# Altium schematic sheet E53_ST1.SchDoc
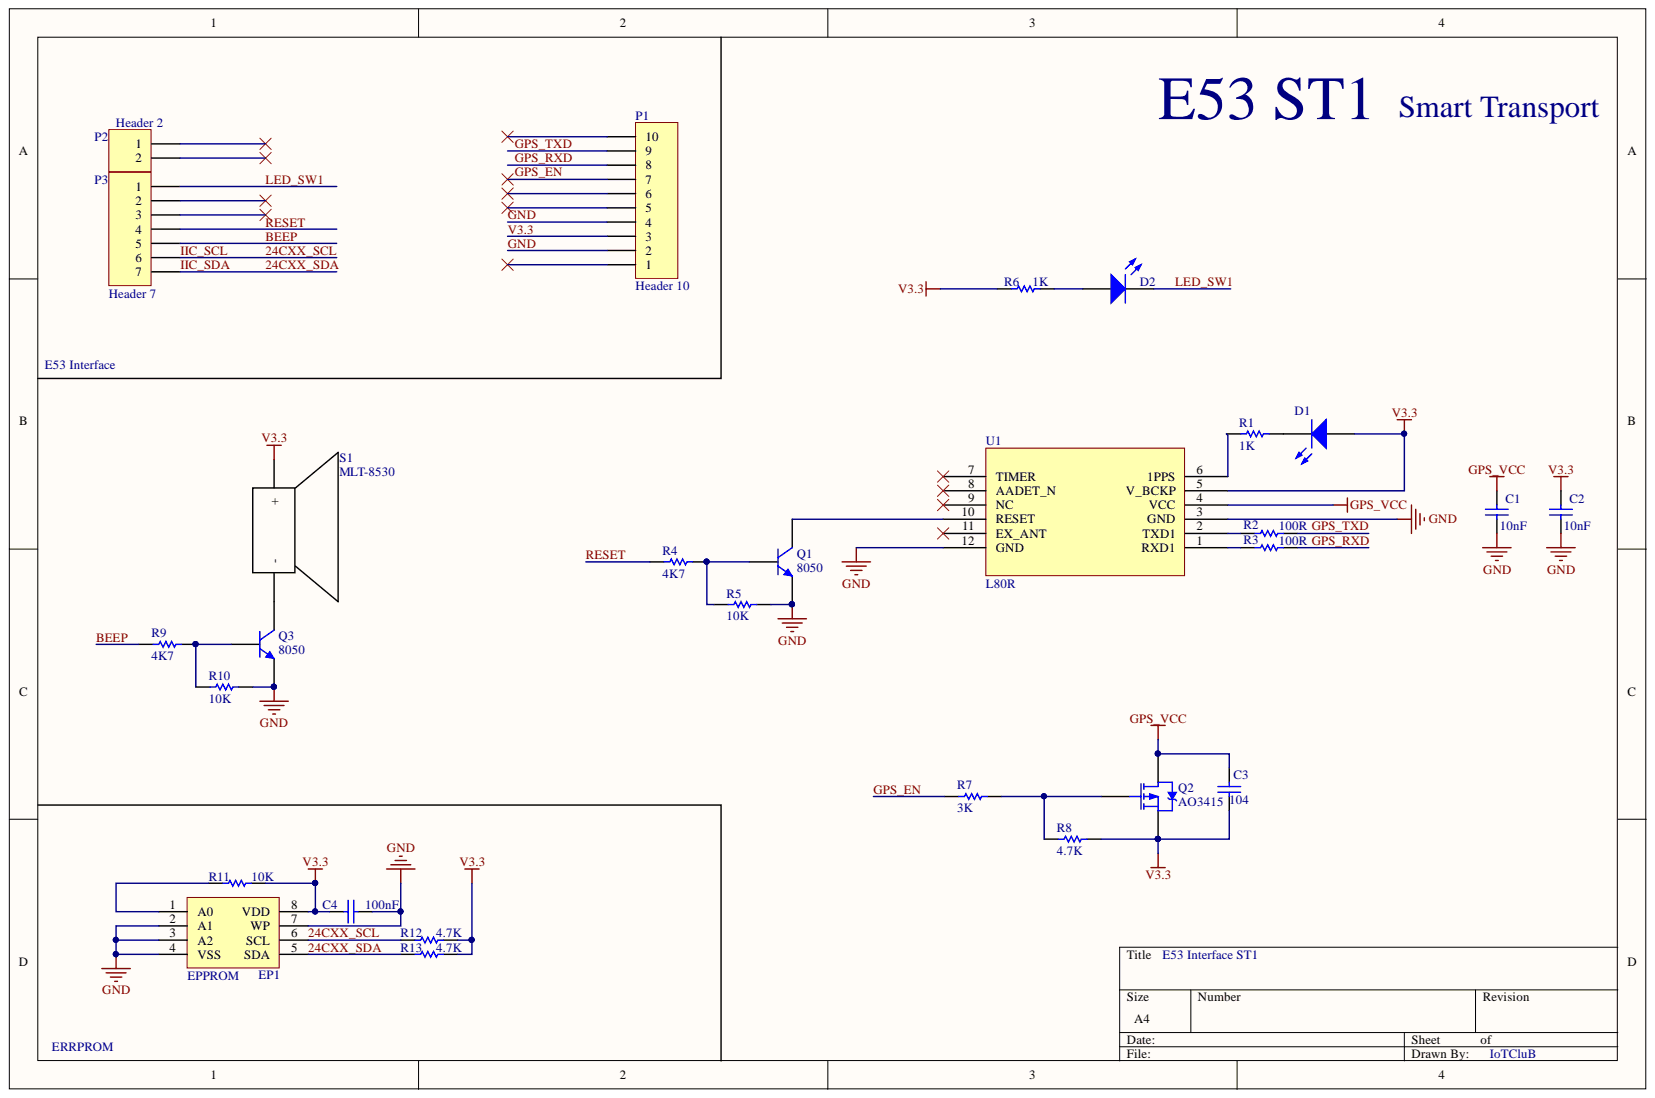
[diagram p0: E53_ST1.SchDoc]

## Parts
C1  10nF  [C0603]
C2  10nF  [C0603]
C3  value 104  [C0603]  (hidden comment: CAP)
C4  100nF  [C0603]
D1  LED  [LED_0805]  (value not drawn)
D2  LED  [LED_0805]
EP1  EPPROM  [SO-8]
P1  Header 10  [HDR1X10]
P2  Header 2  [HDR1X2]
P3  Header 7  [HDR1X7]
Q1  8050  [SOT23_N - 123]
Q2  AO3415  [SOT23_N]
Q3  8050  [SOT23_N - 123]
R1  1K  [R0603]
R2  100R  [R0603]
R3  100R  [R0603]
R4  4K7  [R0603]
R5  10K  [R0603]
R6  1K  [R0603]
R7  3K  [R0603]
R8  4.7K  [R0603]
R9  4K7  [R0603]
R10  10K  [R0603]
R11  10K  [R0603]
R12  4.7K  [R0603]
R13  4.7K  [R0603]
S1  MLT-8530  [MLT8530]
U1  L80R  [L80R]

## Nets
GPS_VCC: C1.1 C3.2 Q2.2 U1.4
24CXX_SCL: EP1.6 P3.6 R12.2
24CXX_SDA: EP1.5 P3.7 R13.2
BEEP: P3.5 R9.1
GND: C1.2 C2.2 C4.1 EP1.2 EP1.3 EP1.4 EP1.7 P1.2 P1.4 Q1.2 Q3.2 R5.1 R10.2 U1.3 U1.12
GPS_EN: P1.7 R7.2
GPS_RXD: P1.8 R3.2
GPS_TXD: P1.9 R2.2
LED_SW1: D2.2 P3.1
RESET: P3.4 R4.1
V3.3: C2.1 C3.1 C4.2 D1.1 EP1.8 P1.3 Q2.3 R6.1 R8.2 R11.2 R12.1 R13.1 S1.1 U1.5
NetD1_2: D1.2 R1.1
NetD2_1: D2.1 R6.2
NetEP1_1: EP1.1 R11.1
NetQ1_1: Q1.1 R4.2 R5.2
NetQ1_3: Q1.3 U1.10
NetQ2_1: Q2.1 R7.1 R8.1
NetQ3_1: Q3.1 R9.2 R10.1
NetQ3_3: Q3.3 S1.2
NetR1_2: R1.2 U1.6
NetR2_1: R2.1 U1.2
NetR3_1: R3.1 U1.1

Not connected: P1 1 5 6 10; P2 1 2; P3 2 3; U1 7 8 9 11

## Library data of the parts
C1 C2 C4 = CAP: Capacitor; Component Kind: Standard; Library Name: Devices.IntLib
C3 = CAP: CAP-0603; Component Kind: Standard; Library Name: Commodity_tracker_LIB.IntLib
D1 D2 = LED1: Typical RED GaAs LED; Component Kind: Standard; LatestRevisionDate: 08-Jul-2005; LatestRevisionNote: Mech Layer 1 removed.; Library Name: Devices.IntLib; PackageDescription: LED; 2 Leads; PackageReference: LED-1; Published: 8-Jun-2000; Simulation: LED1; Publisher: Altium Limited
EP1 = EPPROM: ROM: 24C02; Component Kind: Standard; Library Name: IC.IntLib
P1 = Header 10: Header, 10-Pin; Component Kind: Standard; LatestRevisionDate: 17-Jul-2002; LatestRevisionNote: Re-released for DXP Platform.; Library Name: Connectors.IntLib; Signal Integrity: Connector; Publisher: Altium Limited
P2 = Header 2: Header, 2-Pin; Component Kind: Standard; LatestRevisionDate: 17-Jul-2002; LatestRevisionNote: Re-released for DXP Platform.; Library Name: Connectors.IntLib; Signal Integrity: Connector; Publisher: Altium Limited
P3 = Header 7: Header, 7-Pin; Component Kind: Standard; LatestRevisionDate: 17-Jul-2002; LatestRevisionNote: Re-released for DXP Platform.; Library Name: Connectors.IntLib; Signal Integrity: Connector; Publisher: Altium Limited
Q1 Q3 = NPN: NPN Bipolar Transistor; Code_JEDEC: TO-226-AA; Component Kind: Standard; LatestRevisionDate: 17-Jul-2002; LatestRevisionNote: Re-released for DXP Platform.; Library Name: Devices.IntLib; PackageDescription: TO, Flat Index; 3 In-Line, Axial Leads; Body Dia. 4.8mm; Leads 0.55 x 0.51 mm (max); PackageReference: TO-226-AA; Published: 8-Jun-2000; Signal Integrity: NPN; Simulation: NPN; Publisher: Altium Limited
Q2 = PMOS-2: P-Channel Power MOSFET; Component Kind: Standard; Library Name: Devices.IntLib; Simulation: IRF9510
R1 R6 = Res1: Resistor; Component Kind: Standard; LatestRevisionDate: 17-Jul-2002; LatestRevisionNote: Re-released for DXP Platform.; Library Name: Devices.IntLib; PackageDescription: Axial Device, Thru-Hole; 2 Leads; 0.3 in Pin Spacing; PackageReference: AXIAL-0.3; Published: 8-Jun-2000; Signal Integrity: Res; Simulation: RESISTOR; Value: 1K; Publisher: Altium Limited
R2-R5 R7-R13 = Res1: Resistor; Value: 1K; Component Kind: Standard; LatestRevisionDate: 17-Jul-2002; LatestRevisionNote: Re-released for DXP Platform.; Library Name: Devices.IntLib; PackageDescription: Axial Device, Thru-Hole; 2 Leads; 0.3 in Pin Spacing; PackageReference: AXIAL-0.3; Published: 8-Jun-2000; Signal Integrity: Res; Simulation: RESISTOR; Publisher: Altium Limited
U1 = L80R: Quectel-L80R; Component Kind: Standard; Library Name: Custom.IntLib
S1 = MLT-8530: Component Kind: Standard; Library Name: Custom.IntLib
